# Altium schematic sheet PiggySat-antenna.SchDoc(PiggySat-antenna)
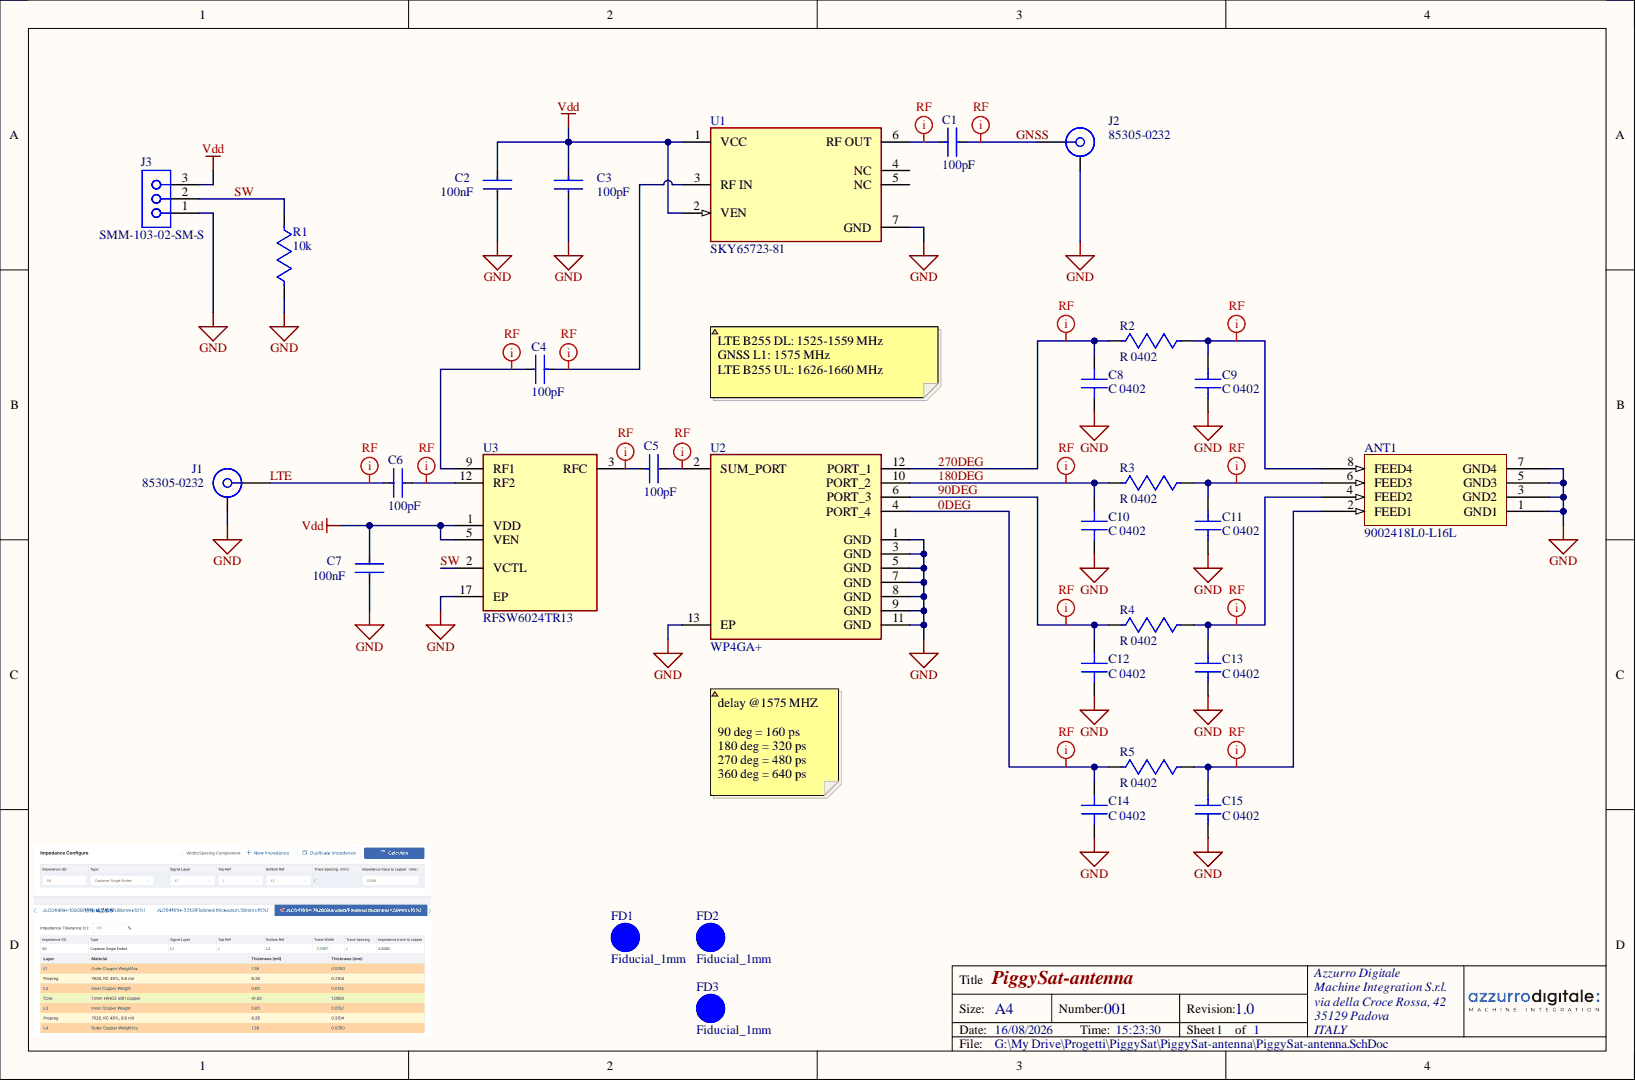
[diagram p0: PiggySat-antenna.SchDoc]

## Parts
ANT1  9002418L0-L16L  [9002418L0-L16L-Footprint-1]
C1  Capacitance: 100pF  [FP-GCM155-0_05-IPC_C]  (hidden comment: GCM1555C1H101JA16D)
C2  Capacitance: 100nF  [FP-GRM155-0_05-MFG]  (hidden comment: GRM155R71C104KA88J)
C3  Capacitance: 100pF  [FP-GCM155-0_05-IPC_C]  (hidden comment: GCM1555C1H101JA16D)
C4  Capacitance: 100pF  [FP-GCM155-0_05-IPC_C]  (hidden comment: GCM1555C1H101JA16D)
C5  Capacitance: 100pF  [FP-GCM155-0_05-IPC_C]  (hidden comment: GCM1555C1H101JA16D)
C6  Capacitance: 100pF  [FP-GCM155-0_05-IPC_C]  (hidden comment: GCM1555C1H101JA16D)
C7  Capacitance: 100nF  [FP-GRM155-0_05-MFG]  (hidden comment: GRM155R71C104KA88J)
C8  C 0402  [cap_0402]  (no BOM)
C9  C 0402  [cap_0402]  (no BOM)
C10  C 0402  [cap_0402]  (no BOM)
C11  C 0402  [cap_0402]  (no BOM)
C12  C 0402  [cap_0402]  (no BOM)
C13  C 0402  [cap_0402]  (no BOM)
C14  C 0402  [cap_0402]  (no BOM)
C15  C 0402  [cap_0402]  (no BOM)
FD1  Fiducial_1mm  [Fiducial_1mm-Footprint-1]  (no BOM)
FD2  Fiducial_1mm  [Fiducial_1mm-Footprint-1]  (no BOM)
FD3  Fiducial_1mm  [Fiducial_1mm-Footprint-1]  (no BOM)
J1  85305-0232  [MOLEX_85305-0232]
J2  85305-0232  [MOLEX_85305-0232]
J3  SMM-103-02-SM-S  [SMM-103-02-SM-S]
R1  10k  [RESC1005X04L]
R2  R 0402  [res_0402]
R3  R 0402  [res_0402]
R4  R 0402  [res_0402]
R5  R 0402  [res_0402]
U1  SKY65723-81  [FP-SKY65723-81-MFG]
U2  WP4GA+  [WP4_12QFN]
U3  RFSW6024TR13  [QFN65P400X400X90-17N]

## Nets
GND: ANT1.1(GND1) ANT1.3(GND2) ANT1.5(GND3) ANT1.7(GND4) C2.2 C3.2 C7.2 C8.1 C9.2 C10.1 C11.2 C12.1 C13.2 C14.1 C15.2 J1.2 J2.2 J3.1 R1.1 U1.7(GND) U2.1(GND) U2.3(GND) U2.5(GND) U2.7(GND) U2.8(GND) U2.9(GND) U2.11(GND) U2.13(EP) U3.17(EP)
Vdd: C2.1 C3.1 C7.1 J3.3 U1.1(VCC) U1.2(VEN) U3.1(VDD) U3.5(VEN)
0DEG: C14.2 R5.1 U2.4(PORT_4)
90DEG: C12.2 R4.1 U2.6(PORT_3)
180DEG: C10.2 R3.1 U2.10(PORT_2)
270DEG: C8.2 R2.1 U2.12(PORT_1)
GNSS: C1.2 J2.1
LTE: C6.1 J1.1
SW: J3.2 R1.2 U3.2(VCTL)
NetANT1_2: ANT1.2(FEED1) C15.1 R5.2
NetANT1_4: ANT1.4(FEED2) C13.1 R4.2
NetANT1_6: ANT1.6(FEED3) C11.1 R3.2
NetANT1_8: ANT1.8(FEED4) C9.1 R2.2
NetC1_1: C1.1 U1.6(RF_OUT)
NetC4_1: C4.1 U1.3(RF_IN)
NetC4_2: C4.2 U3.9(RF1)
NetC5_1: C5.1 U3.3(RFC)
NetC5_2: C5.2 U2.2(SUM_PORT)
NetC6_2: C6.2 U3.12(RF2)

Not connected: U1 4(NC) 5(NC)

## Library data of the parts
ANT1 = 9002418L0-L16L: Internal Antenna, L-Band, Cap/1.7/1.6Dbi Rohs Compliant: Yes |Kyocera Avx 9002418L0-L16L; Component Kind: Standard; Introduction Date: 2025-04-03; Lifecycle Status: Production; REACH SVHC: Yes; RoHS: Compliant; Library Name: PiggySat.IntLib
C1 C3-C6 = CMP-2008-03694-3: Ceramic Capacitor for Automotive 100pF ±5% 50VDC C0G 0402 Paper T/R; Voltage: 50V; Case Code (Imperial): 0402; Case Code (Metric): 1005; Case/Package: 0402; Component Kind: Standard; Depth: 0.5mm; Dielectric: C0G; Height: 0.5mm; Lead Free: Lead Free; Length: 1mm; Library Name: Altium Content Vault; Max Operating Temperature: 125°C; Min Operating Temperature: -55°C; Mount: Surface Mount; Package Quantity: 10000; Packaging: Tape and Reel; Radiation Hardening: No; Ratings: AEC-Q200; REACH SVHC: No SVHC; Termination: SMD/SMT; Thickness: 0.022inch; Tolerance: 5%; Voltage Rating: 50V; Voltage Rating (DC): 50V; Weight: 0.000212oz; Width: 0.02inch
C2 C7 = CMP-2008-03928-2: Manufacturer Lifecycle Status: NRND; NexarPartId: 9223238; Dielectric: X7R; Material: Ceramic; Tolerance: 10%; Voltage: 16V; Case Code (Imperial): 0402; Case Code (Metric): 1005; Case/Package: 0402; Component Kind: Standard; Depth: 500µm; Height: 550µm; Lead Free: Lead Free; Length: 1mm; Library Name: Altium Content Vault; Max Operating Temperature: 125°C; Min Operating Temperature: -55°C; Mount: Surface Mount; Number of Pins: 2; Packaging: Cut Tape; Radiation Hardening: No; REACH SVHC: No SVHC; RoHS: Compliant; Schedule B: 8532240020, 8532240020; Termination: SMD/SMT; Thickness: 500µm; Voltage Rating: 16V; Voltage Rating (DC): 16V; Width: 500µm
C8-C15 = CAP_0402: Description: C 0402; Library Name: Altium_Library.IntLib
FD1-FD3 = CMP-00017-7: 1mm fiducial marker; Library Name: AZZURRODIGITALE MACHINE INTEGRATION SRL
J1 J2 = 85305-0232: Circular Connector, SMP STRAIGHT PLUG, PCB, 85305 SMP RF Connectors Series; Manufacturer: MOLEX; Component Kind: Standard; ComponentLink1Description: Manufacturer URL; ComponentLink1URL: http://www.rosenberger.de; ComponentLink2Description: Datasheet; ComponentLink2URL: http://rosenberger.de/ok/images/documents/db/32K145-400L5.pdf; ComponentLink3Description: Package Specification; ComponentLink3URL: http://rosenberger.de/ok/images/documents/db/32K145-400L5.pdf; ComponentLink4Description: Series Overview / Design Note; ComponentLink4URL: http://rosenberger.de/ok/images/documents/spez/32.pdf; ComponentLink5Description: Webshop / RFQ; ComponentLink5URL: http://www.rosenberger.com/en/contact/sales/; Mounting Technology: Surface Mount; System: Connector; Library Name: PiggySat.IntLib
J3 = SMM-103-02-SM-S: SMM Series 2.00 mm Tiger Eye™ High-Reliability Socket Strip; System: Connector; Component Kind: Standard; Library Name: PiggySat.IntLib
R1 = CMP-009-00001-2: Res 10k 0402; Component Kind: Standard; Library Name: AZZURRODIGITALE MACHINE INTEGRATION SRL
R2-R5 = RES_0402: Component Kind: Standard; Description: R 0402; Library Name: Altium_Library.IntLib
U1 = CMP-85924-000002-1: IC RF AMP LNA GPS/GNSS SPFS; Component Kind: Standard; Library Name: Altium Content Vault; RoHS Compliant: Yes
U2 = WP4GA+: 4 Ways MMIC Power Splitter, 1420 - 1660 MHz, 50Ω; MF: Mini-Circuits; MP: WP4G1+; Check_prices: https://www.snapeda.com/parts/WP4G1+/Mini-Circuits/view-part/?ref=eda; Component Kind: Standard; Package: VQFN-12 Mini-Circuits; SnapEDA_Link: https://www.snapeda.com/parts/WP4G1+/Mini-Circuits/view-part/?ref=snap; Library Name: PiggySat.IntLib
U3 = RFSW6024TR13: 5 - 6000 MHz Absorptive High Isolation SPDT Switch; Manufacturer_Name: Qorvo; Geometry.Height: 0.9mm; Component Kind: Standard; Datasheet Link: https://www.qorvo.com/products/d/da001155; Manufacturer_Part_Number: RFSW6024TR13; Mouser Part Number: 772-RFSW6024TR13; Mouser Price/Stock: https://www.mouser.co.uk/ProductDetail/Qorvo/RFSW6024TR13?qs=u4fy%2FsgLU9NLk9lDlNNCYg%3D%3D; Library Name: PiggySat.IntLib
